# Altium schematic sheet [02] - GSM.SchDoc
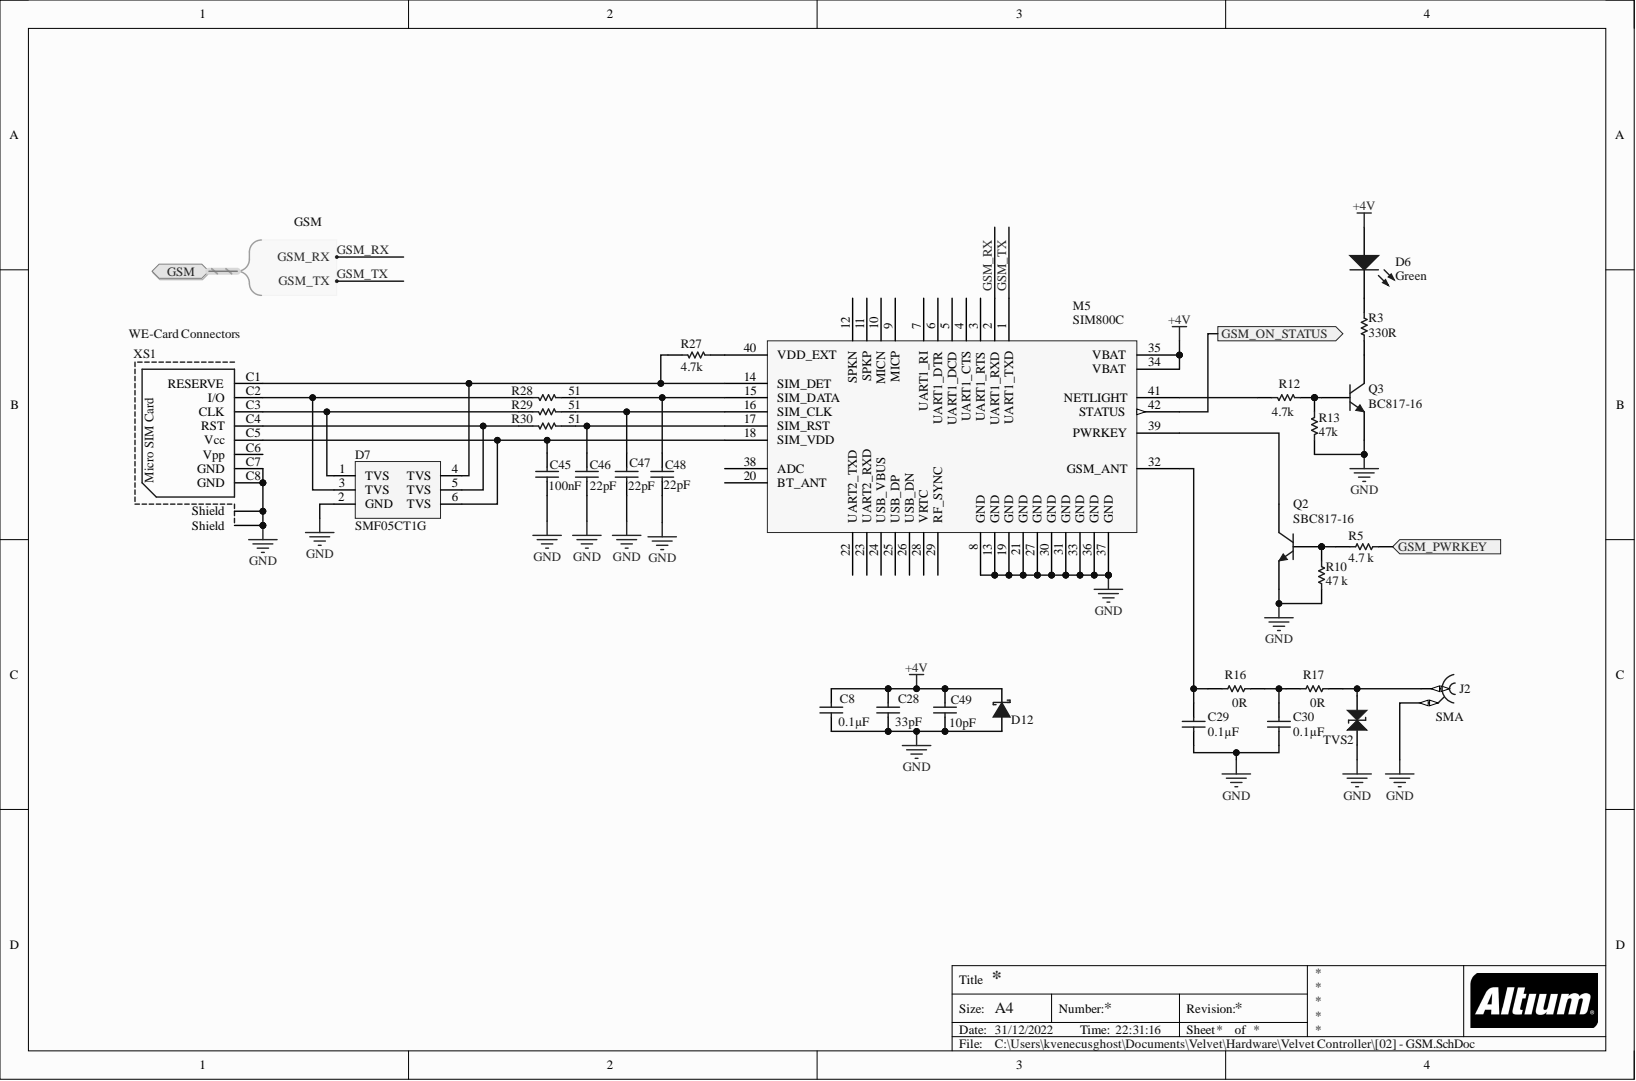
[diagram p0: [02] - GSM.SchDoc]

## Parts
C8  Capacitance: 0.1µF  [0603_C]  (hidden comment: Capacitor)
C28  Capacitance: 33pF  [0603_C]  (hidden comment: Capacitor)
C29  Capacitance: 0.1µF  [0603_C]  (hidden comment: Capacitor)
C30  Capacitance: 0.1µF  [0603_C]  (hidden comment: Capacitor)
C45  Capacitance: 100nF  [0603_C]  (hidden comment: Capacitor)
C46  Capacitance: 22pF  [0603_C]  (hidden comment: Capacitor)
C47  Capacitance: 22pF  [0603_C]  (hidden comment: Capacitor)
C48  Capacitance: 22pF  [0603_C]  (hidden comment: Capacitor)
C49  Capacitance: 10pF  [0603_C]  (hidden comment: Capacitor)
D6  Colour: Green  [Led 0805 green]  (hidden comment: LED)
D7  SMF05CT1G  [? TVS SMF05CT]
D12  D Schottky_1  [SOD-323]  (value not drawn)
J2  SMA  [AMPHENOL_132134]
M5  SIM800C  [GSM SIM800C]
Q2  SBC817-16  [SOT23-3]
Q3  BC817-16  [SOT23-3]
R3  Resistance: 330R  [0603_R]  (hidden comment: Res1)
R5  Resistance: 4.7 k  [0603_R]  (hidden comment: Res1)
R10  Resistance: 47 k  [0603_R]  (hidden comment: Res1)
R12  Resistance: 4.7k  [0603_R]  (hidden comment: Res1)
R13  Resistance: 47k  [0603_R]  (hidden comment: Res1)
R16  Resistance: 0R  [0603_R]  (hidden comment: Res1)
R17  Res1  [0603_R]  (value not drawn)
R27  Resistance: 4.7k  [0603_R]  (hidden comment: Res1)
R28  Res1  [0603_R]  (value not drawn)
R29  Res1  [0603_R]  (value not drawn)
R30  Res1  [0603_R]  (value not drawn)
TVS2  LXE15AAA1-153  [LXES15_MUR-L]  (value not drawn)
XS1  WE-Card Connectors  [693022010811]

## Ports
GSM, GSM.GSM_RX, GSM.GSM_TX, GSM_ON_STATUS, GSM_PWRKEY

## Nets
+4V: C8.2 C28.2 C49.2 D6.1 D12.1 M5.34(VBAT) M5.35(VBAT)
GND: C8.1 C28.1 C29.1 C30.1 C45.1 C46.1 C47.1 C48.1 C49.1 D7.2(GND) D12.2 J2.2 M5.8(GND) M5.13(GND) M5.19(GND) M5.21(GND) M5.27(GND) M5.30(GND) M5.31(GND) M5.33(GND) M5.36(GND) M5.37(GND) Q2.2 Q3.2 R10.1 R13.1 TVS2.1 XS1.C7(GND) XS1.C8(GND) XS1.Z1(Shield) XS1.Z2(Shield)
GSM_ON_STATUS: M5.42(STATUS) ; port GSM_ON_STATUS
GSM_PWRKEY: R5.2 ; port GSM_PWRKEY
GSM_RX: M5.2(UART1_RXD) ; port GSM
GSM_TX: M5.1(UART1_TXD) ; port GSM
NetC29_2: C29.2 M5.32(GSM_ANT) R16.2
NetC30_2: C30.2 R16.1 R17.2
NetC45_2: C45.2 D7.6(TVS) M5.18(SIM_VDD) XS1.C5(Vcc)
NetC46_2: C46.2 M5.17(SIM_RST) R30.1
NetC47_2: C47.2 M5.16(SIM_CLK) R29.1
NetC48_2: C48.2 M5.15(SIM_DATA) R28.1
NetD6_2: D6.2 R3.2
NetD7_1: D7.1(TVS) R29.2 XS1.C3(CLK)
NetD7_3: D7.3(TVS) R28.2 XS1.C2(I/O)
NetD7_4: D7.4(TVS) M5.14(SIM_DET) R27.2 XS1.C1(RESERVE)
NetD7_5: D7.5(TVS) R30.2 XS1.C4(RST)
NetJ2_1: J2.1 R17.1 TVS2.2
NetM5_39: M5.39(PWRKEY) Q2.3
NetM5_40: M5.40(VDD_EXT) R27.1
NetM5_41: M5.41(NETLIGHT) R12.1
NetQ2_1: Q2.1 R5.1 R10.2
NetQ3_1: Q3.1 R12.2 R13.2
NetQ3_3: Q3.3 R3.1

Not connected: M5 3(UART1_RTS) 4(UART1_CTS) 5(UART1_DCD) 6(UART1_DTR) 7(UART1_RI) 9(MICP) 10(MICN) 11(SPKP) 12(SPKN) 20(BT_ANT) 22(UART2_TXD) 23(UART2_RXD) 24(USB_VBUS) 25(USB_DP) 26(USB_DN) 28(VRTC) 29(RF_SYNC) 38(ADC); XS1 C6(Vpp)

## Library data of the parts
C8 C28-C30 C45-C49 = 1: Chip Capacitor Nonpolar; Manufacturer: Kemet; Manufacturer Part Number: C0603C104K5RACTU; Database Table Name: Capacitors$; Temperature Coefficient: X7R; Tolerance: ±10%; Voltage - Rated: 50V; Applications: General Purpose; Category: Capacitors; Component Kind: Standard; ComponentLink1Description: http://www.kemet.com/docfinder?Partnumber=C0603C104K5RACTU; ComponentLink1URL: http://www.kemet.com/docfinder?Partnumber=C0603C104K5RACTU; Features: -; Height - Seated (Max): -; Lead Spacing: -; Lead Style: -; Library Name: Altium_Library.DbLib; Mounting Type: Surface Mount, MLCC; Operating Temperature: -55°C ~ 125°C; Package / Case: 0603 (1608 Metric); Packaging: Cut Tape (CT); Pricing 1: 1=0.1, 10=0.041, 50=0.0222, 100=0.0187, 250=0.01532, 500=0.0131, 1000=0.0102 (USD); Ratings: -; RoHS: RoHS Compliant; Size / Dimension: 0.063" L x 0.031" W (1.60mm x 0.80mm); Stock 1: 3992655; Supplier 1: Digi-Key; Supplier Part Number 1: 399-5089-1-ND; Thickness (Max): 0.034" (0.87mm)
D6 = 3: Led 0805; Manufacturer: Vishay Lite-On; Manufacturer Part Number: LTST-S220GKT; Database Table Name: Devises$; Dominant Wavelength: 569nm; Forward Current: 30mA; Forward Voltage: 2.1V; Lens Colour: Clear,Colorless; Lens Style: Clear,Diffused,Colorless,Rectangular; Lens Transparency: Clear; Luminous Intensity: 10mcd; Number of Elements: 1; Power Dissipation: 100mW; Reverse Voltage: 5V; Test Current: 20mA; Viewing Angle: 130°; Wavelength: 565nm; Case Code (Imperial): 0805; Case Code (Metric): 2012; Case/Package: 0805; Component Kind: Standard; ComponentLink1Description: https://datasheet.ciiva.com/2110/ltst-s220gkt-2110016.pdf; ComponentLink1URL: https://datasheet.ciiva.com/2110/ltst-s220gkt-2110016.pdf; ComponentLink2Description: https://datasheet.ciiva.com/11775/0900766b80dc0b6e-11775442.pdf; ComponentLink2URL: https://datasheet.ciiva.com/11775/0900766b80dc0b6e-11775442.pdf; ComponentLink3Description: https://datasheet.ciiva.com/15118/getdatasheetpartid-221136-15118117.pdf; ComponentLink3URL: https://datasheet.ciiva.com/15118/getdatasheetpartid-221136-15118117.pdf; ComponentLink4Description: https://datasheet.ciiva.com/2110/ltst-s220gkt-2110017.pdf; ComponentLink4URL: https://datasheet.ciiva.com/2110/ltst-s220gkt-2110017.pdf; ComponentLink5Description: https://datasheet.ciiva.com/16444/ltst-s220gkt-16444773.pdf; ComponentLink5URL: https://datasheet.ciiva.com/16444/ltst-s220gkt-16444773.pdf; Depth: 0.6mm; Height: 0.6mm; ID: 3; Illumination Colour: Green; Lead Free: Lead Free; Length: 2.1mm; Library Name: Altium_Library.DbLib; Max Operating Temperature: 85°C; Min Operating Temperature: -55°C; Mount: Surface Mount; Number of LEDs: 1; Number of Pins: 2; Package Quantity: 1; Packaging: Tape and Reel; Power Rating: 100mW; Pricing 1: 1=0.27, 10=0.199, 25=0.1464, 100=0.1045, 250=0.07108, 500=0.0627, 1000=0.04912 (USD); Radiation Hardening: No; Stock 1: 87001; Supplier 1: Digi-Key; Supplier Part Number 1: 160-1218-6-ND; Width: 1mm
D7 = SMF05CT1G: 5-Line Transient Voltage  Suppressor Array; Manufacturer: ON Semiconductor; Capacitance @ Frequency: 80pF @ 1MHz; Current - Peak Pulse (10/1000µs): 8A (8/20µs); Power - Peak Pulse: 100W; Power Line Protection: No; Type: Zener; Unidirectional Channels: 5; Voltage - Breakdown (Min): 6.2V; Voltage - Clamping (Max) @ Ipp: 12.5V; Voltage - Reverse Standoff (Typ): 5V (Max); Applications: General Purpose; Bidirectional Channels: -; Category: Circuit Protection; Component Kind: Standard; ComponentLink1Description: http://www.onsemi.com/pub_link/Collateral/SMF05C-D.PDF; ComponentLink1URL: http://www.onsemi.com/pub_link/Collateral/SMF05C-D.PDF; Library Name: SIM68V.SchLib; Manufacturer Part Number: SMF05CT1G; Mounting Type: Surface Mount; Operating Temperature: -40°C ~ 125°C; Package / Case: 6-TSSOP, SC-88, SOT-363; Packaging: Cut Tape (CT); Pricing 1: 1=0.59, 10=0.413, 25=0.3392, 100=0.2709, 250=0.19736, 500=0.16026, 1000=0.12319 (USD); RoHS: RoHS Compliant; Stock 1: 13951; Supplier 1: Digi-Key; Supplier Device Package: SC-88/SC70-6/SOT-363; Supplier Part Number 1: SMF05CT1GOSCT-ND
D12 = D Schottky_1: SMAZ16-13-F; Manufacturer: Diodes Incorporated; Pd - Power Dissipation: 1 W; Vz - Zener Voltage: 16 V; Zener Current: 0.5 uA; Component Kind: Standard; Manufacturer Part Number: SMAZ16-13-F; Maximum Operating Temperature: + 150 C; Minimum Operating Temperature: - 65 C; Mounting Style: SMD/SMT; Package / Case: SMA-2; Product Category: Zener Diodes; Supplier Part Number: SMAZ16-FDICT-ND; Library Name: Blue Tractor-2021.SchLib
J2 = AMPHENOL_132134: Manufacturer: TE Connectivity; Manufacturer Part Number: 5-1814832-1; Body Material: Zinc; Body Plating: Gold; Connector System: Cable-to-Board; Connector Type: Jack,SMA; Dielectric Material: PTFE; Frequency: 3GHz; Gender: Female; Impedance: 50R; Insulation Resistance: 5GR; Number of Positions: 1; Plating: Nickel; Polarity: Normal; Sealable: No (Without); Tail Length: 4mm; Wire/Cable Type: Coaxial; Case/Package: SMA; Component Kind: Standard; Contact Material: Bronze; Contact Plating: Gold; Database Table Name: AMPHENOL; Depth: 6.4mm; ELV: Compliant; Family: SMA; Fastening Type: Threaded; Insulation Material: Polytetrafluoroethylene; Lead Free: Lead Free; Length: 0.532inch; Library Name: Connectors.DbLib; Max Frequency: 3GHz; Max Operating Temperature: 185°C; Min Operating Temperature: -67°C; Mount: PCB,Through Hole; Orientation: Straight; Package Quantity: 1; Packaging: Box; Pricing 1: 1=2.21, 10=2.121, 25=1.944, 50=1.8556, 100=1.7673, 250=1.54636, 500=1.50218, 1000=1.32545 (USD); Radiation Hardening: No; REACH SVHC: No SVHC; Stock 1: 20161; Supplier 1: Digi-Key; Supplier Part Number 1: A97594-ND; Termination: Through Hole; Author: CERN DEM JLC
M5 = SIM800C: GSM/GPS module; Component Kind: Standard; Library Name: Blue Tractor-2021.SchLib
Q2 Q3 = BC817-16: NPN General-purpose Transistor; Manufacturer: ON Semiconductor; Manufacturer Part Number: BC817-16LT3G; Collector Base Voltage (VCBO): 50V; Collector Emitter Breakdown Voltage: 45V; Collector Emitter Saturation Voltage: 700mV; Element Configuration: Single; Emitter Base Voltage (VEBO): 5V; Frequency: 100MHz; hFE Min: 100; Max Collector Current: 500mA; Max Power Dissipation: 300mW; Number of Elements: 1; Case/Package: SOT-23-3; Collector Emitter Voltage (VCEO): 45V; Component Kind: Standard; ComponentLink1Description: http://www.mccsemi.com/up_pdf/BC817-16~BC817-40(SOT-23).pdf; ComponentLink1URL: http://www.mccsemi.com/up_pdf/BC817-16~BC817-40(SOT-23).pdf; ComponentLink2Description: https://datasheet.ciiva.com/15139/getdatasheetpartid-84401-15139109.pdf; ComponentLink2URL: https://datasheet.ciiva.com/15139/getdatasheetpartid-84401-15139109.pdf; ComponentLink3Description: https://datasheet.ciiva.com/980/bc817-16lt1-d-980715.pdf; ComponentLink3URL: https://datasheet.ciiva.com/980/bc817-16lt1-d-980715.pdf; ComponentLink4Description: https://datasheet.ciiva.com/26115/bc817-16lt1-d-26115598.pdf; ComponentLink4URL: https://datasheet.ciiva.com/26115/bc817-16lt1-d-26115598.pdf; ComponentLink5Description: https://datasheet.ciiva.com/11204/bc817-16lt1-d-11204335.pdf; ComponentLink5URL: https://datasheet.ciiva.com/11204/bc817-16lt1-d-11204335.pdf; Contact Plating: Tin,Matte; Gain Bandwidth Product: 100MHz; Halogen Free: Halogen Free; Lead Free: Lead Free; Max Breakdown Voltage: 45V; Max Operating Temperature: 150°C; Min Operating Temperature: -55°C; Number of Pins: 3; Package Quantity: 10000; Packaging: Tape and Reel; Polarity: NPN; Power Dissipation: 300mW; Pricing 1: 1=0.13, 10=0.121, 100=0.0658, 500=0.04048, 1000=0.02759 (USD); Radiation Hardening: No; Stock 1: 22032; Supplier 1: Digi-Key; Supplier Part Number 1: BC817-16LT3GOSDKR-ND; Transition Frequency: 100MHz
  Q2: Signal Integrity: BC817-16; Simulation: QBC817-16; Library Name: Blue Tractor-2021.SchLib
  Q3: Library Name: Philips Discrete BJT - Low Power.IntLib
R3 = Res1: Chip Resistor; Manufacturer: Panasonic; Manufacturer Part Number: ERJ-3GEYJ103V; Max Power Dissipation: 100mW; Temperature Coefficient: 200ppm/°C; Tolerance: 5%; Voltage Rating: 75V; Voltage Rating (DC): 50V; Case Code (Imperial): 0603; Case Code (Metric): 1608; Case/Package: 0603; Component Kind: Standard; ComponentLink1Description: https://datasheet.ciiva.com/34303/2007265-34303757.pdf; ComponentLink1URL: https://datasheet.ciiva.com/34303/2007265-34303757.pdf; ComponentLink2Description: https://datasheet.ciiva.com/11809/0900766b80f806dd-11809566.pdf; ComponentLink2URL: https://datasheet.ciiva.com/11809/0900766b80f806dd-11809566.pdf; ComponentLink3Description: https://datasheet.ciiva.com/28514/1678796-28514213.pdf; ComponentLink3URL: https://datasheet.ciiva.com/28514/1678796-28514213.pdf; ComponentLink4Description: https://datasheet.ciiva.com/30147/4aoa0000ce1-30147029.pdf; ComponentLink4URL: https://datasheet.ciiva.com/30147/4aoa0000ce1-30147029.pdf; ComponentLink5Description: https://datasheet.ciiva.com/46371/1678796-46371909.pdf; ComponentLink5URL: https://datasheet.ciiva.com/46371/1678796-46371909.pdf; ComponentLink6Description: https://datasheet.ciiva.com/24133/aoa0000ce1-37422-24133064.pdf; ComponentLink6URL: https://datasheet.ciiva.com/24133/aoa0000ce1-37422-24133064.pdf; ComponentLink7Description: https://datasheet.ciiva.com/46385/1678796-46385240.pdf; ComponentLink7URL: https://datasheet.ciiva.com/46385/1678796-46385240.pdf; ComponentLink8Description: https://datasheet.ciiva.com/17212/aoa0000ce1-17212106.pdf; ComponentLink8URL: https://datasheet.ciiva.com/17212/aoa0000ce1-17212106.pdf; ComponentLink9Description: https://datasheet.ciiva.com/9117/aoa0000ce1-9117038.pdf; ComponentLink9URL: https://datasheet.ciiva.com/9117/aoa0000ce1-9117038.pdf; ComponentLink10Description: https://datasheet.ciiva.com/16740/aoa0000ce1-16740655.pdf; ComponentLink10URL: https://datasheet.ciiva.com/16740/aoa0000ce1-16740655.pdf; ComponentLink11Description: https://datasheet.ciiva.com/48531/aoa0000ce1-48531887.pdf; ComponentLink11URL: https://datasheet.ciiva.com/48531/aoa0000ce1-48531887.pdf; Composition: Thick Film; Contact Plating: Tin; Depth: 0.8mm; Features: Automotive AEC-Q200; Height: 0.45mm; Lead Free: Lead Free; Length: 1.6mm; Max Operating Temperature: 155°C; Military Standard: Not; Min Operating Temperature: -55°C; Mount: Surface Mount; Number of Terminations: 2; Package Quantity: 1; Packaging: Tape and Reel; Power Rating: 100mW; Pricing 1: 1=0.1, 10=0.054, 100=0.022, 1000=0.00988, 2500=0.00858 (USD); REACH SVHC: No SVHC; Stock 1: 10740434; Supplier 1: Digi-Key; Supplier Part Number 1: P10KGDKR-ND; Termination: SMD/SMT; Weight: 7.1E-05oz; Width: 0.8mm; Working Voltage: 75V; Library Name: Blue Tractor-2021.SchLib
R5 R10 = 1: Chip Resistor; Manufacturer: Panasonic; Manufacturer Part Number: ERJ-3GEYJ103V; Database Table Name: Resistors$; Max Power Dissipation: 100mW; Temperature Coefficient: 200ppm/°C; Tolerance: 5%; Voltage Rating: 75V; Voltage Rating (DC): 50V; Case Code (Imperial): 0603; Case Code (Metric): 1608; Case/Package: 0603; Component Kind: Standard; ComponentLink1Description: https://datasheet.ciiva.com/34303/2007265-34303757.pdf; ComponentLink1URL: https://datasheet.ciiva.com/34303/2007265-34303757.pdf; ComponentLink2Description: https://datasheet.ciiva.com/11809/0900766b80f806dd-11809566.pdf; ComponentLink2URL: https://datasheet.ciiva.com/11809/0900766b80f806dd-11809566.pdf; ComponentLink3Description: https://datasheet.ciiva.com/28514/1678796-28514213.pdf; ComponentLink3URL: https://datasheet.ciiva.com/28514/1678796-28514213.pdf; ComponentLink4Description: https://datasheet.ciiva.com/30147/4aoa0000ce1-30147029.pdf; ComponentLink4URL: https://datasheet.ciiva.com/30147/4aoa0000ce1-30147029.pdf; ComponentLink5Description: https://datasheet.ciiva.com/46371/1678796-46371909.pdf; ComponentLink5URL: https://datasheet.ciiva.com/46371/1678796-46371909.pdf; ComponentLink6Description: https://datasheet.ciiva.com/24133/aoa0000ce1-37422-24133064.pdf; ComponentLink6URL: https://datasheet.ciiva.com/24133/aoa0000ce1-37422-24133064.pdf; ComponentLink7Description: https://datasheet.ciiva.com/46385/1678796-46385240.pdf; ComponentLink7URL: https://datasheet.ciiva.com/46385/1678796-46385240.pdf; ComponentLink8Description: https://datasheet.ciiva.com/17212/aoa0000ce1-17212106.pdf; ComponentLink8URL: https://datasheet.ciiva.com/17212/aoa0000ce1-17212106.pdf; ComponentLink9Description: https://datasheet.ciiva.com/9117/aoa0000ce1-9117038.pdf; ComponentLink9URL: https://datasheet.ciiva.com/9117/aoa0000ce1-9117038.pdf; ComponentLink10Description: https://datasheet.ciiva.com/16740/aoa0000ce1-16740655.pdf; ComponentLink10URL: https://datasheet.ciiva.com/16740/aoa0000ce1-16740655.pdf; ComponentLink11Description: https://datasheet.ciiva.com/48531/aoa0000ce1-48531887.pdf; ComponentLink11URL: https://datasheet.ciiva.com/48531/aoa0000ce1-48531887.pdf; Composition: Thick Film; Contact Plating: Tin; Depth: 0.8mm; Features: Automotive AEC-Q200; Height: 0.45mm; Lead Free: Lead Free; Length: 1.6mm; Library Name: Altium_Library.DbLib; Max Operating Temperature: 155°C; Military Standard: Not; Min Operating Temperature: -55°C; Mount: Surface Mount; Number of Terminations: 2; Package Quantity: 1; Packaging: Tape and Reel; Power Rating: 100mW; Pricing 1: 1=0.1, 10=0.054, 100=0.022, 1000=0.00988, 2500=0.00858 (USD); REACH SVHC: No SVHC; Stock 1: 10740434; Supplier 1: Digi-Key; Supplier Part Number 1: P10KGDKR-ND; Termination: SMD/SMT; Weight: 7.1E-05oz; Width: 0.8mm; Working Voltage: 75V
R12 R13 R27 = 1: Chip Resistor; Manufacturer: Panasonic; Manufacturer Part Number: ERJ-3EKF3300V; Database Table Name: Resistors$; Max Power Dissipation: 100mW; Temperature Coefficient: 100ppm/°C; Tolerance: 1%; Voltage Rating: 75V; Case Code (Imperial): 0603; Case Code (Metric): 1608; Case/Package: 0603; Component Kind: Standard; ComponentLink1Description: http://industrial.panasonic.com/www-cgi/jvcr13pz.cgi?E+PZ+3+AOA0002+ERJ6ENF3000V+7+WW; ComponentLink1URL: http://industrial.panasonic.com/www-cgi/jvcr13pz.cgi?E+PZ+3+AOA0002+ERJ6ENF3000V+7+WW; ComponentLink2Description: https://datasheet.ciiva.com/7886/aoa0000ce2-7886729.pdf; ComponentLink2URL: https://datasheet.ciiva.com/7886/aoa0000ce2-7886729.pdf; ComponentLink3Description: https://datasheet.ciiva.com/48531/aoa0000ce2-48531001.pdf; ComponentLink3URL: https://datasheet.ciiva.com/48531/aoa0000ce2-48531001.pdf; ComponentLink4Description: https://datasheet.ciiva.com/22721/426256-22721099.pdf; ComponentLink4URL: https://datasheet.ciiva.com/22721/426256-22721099.pdf; ComponentLink5Description: https://datasheet.ciiva.com/46373/1678782-46373899.pdf; ComponentLink5URL: https://datasheet.ciiva.com/46373/1678782-46373899.pdf; ComponentLink6Description: https://datasheet.ciiva.com/9117/aoa0000ce2-9117132.pdf; ComponentLink6URL: https://datasheet.ciiva.com/9117/aoa0000ce2-9117132.pdf; ComponentLink7Description: https://datasheet.ciiva.com/46373/1678782-46373900.pdf; ComponentLink7URL: https://datasheet.ciiva.com/46373/1678782-46373900.pdf; Composition: Thick Film; Contact Plating: Tin; Depth: 0.8mm; Features: Automotive AEC-Q200; Height: 0.022inch; Lead Free: Lead Free; Length: 1.6mm; Library Name: Altium_Library.DbLib; Max Operating Temperature: 155°C; Military Standard: Not; Min Operating Temperature: -55°C; Mount: Surface Mount; Number of Pins: 2; Number of Terminations: 2; Package Quantity: 1; Packaging: Tape and Reel; Power Rating: 100mW; Pricing 1: 1=0.1, 10=0.079, 100=0.0323, 1000=0.01449, 2500=0.01258 (USD); Radiation Hardening: No; REACH SVHC: No SVHC; Stock 1: 924496; Supplier 1: Digi-Key; Supplier Part Number 1: P330HDKR-ND; Termination: SMD/SMT; Voltage Rating (DC): 75V; Weight: 7.1E-05oz; Width: 0.8mm
R16 = 1: Chip Resistor; Manufacturer: Panasonic; Manufacturer Part Number: ERJ-3GEY0R00V; Database Table Name: Resistors$; Max Power Dissipation: 100mW; Temperature Coefficient: 600ppm/°C; Tolerance: 0%; Voltage Rating: 75V; Voltage Rating (DC): 50V; Case Code (Imperial): 0603; Case Code (Metric): 1608; Case/Package: 0603; Component Kind: Standard; ComponentLink1Description: https://datasheet.ciiva.com/27055/1935748-27055113.pdf; ComponentLink1URL: https://datasheet.ciiva.com/27055/1935748-27055113.pdf; ComponentLink2Description: https://datasheet.ciiva.com/11808/0900766b80f806dd-11808207.pdf; ComponentLink2URL: https://datasheet.ciiva.com/11808/0900766b80f806dd-11808207.pdf; ComponentLink3Description: https://datasheet.ciiva.com/48532/aoa0000ce1-48532165.pdf; ComponentLink3URL: https://datasheet.ciiva.com/48532/aoa0000ce1-48532165.pdf; ComponentLink4Description: https://datasheet.ciiva.com/43460/1678796-43460647.pdf; ComponentLink4URL: https://datasheet.ciiva.com/43460/1678796-43460647.pdf; ComponentLink5Description: https://datasheet.ciiva.com/28695/1678796-28695833.pdf; ComponentLink5URL: https://datasheet.ciiva.com/28695/1678796-28695833.pdf; ComponentLink6Description: https://datasheet.ciiva.com/5959/1678796-5959707.pdf; ComponentLink6URL: https://datasheet.ciiva.com/5959/1678796-5959707.pdf; ComponentLink7Description: https://datasheet.ciiva.com/16834/aoa0000ce1-16834828.pdf; ComponentLink7URL: https://datasheet.ciiva.com/16834/aoa0000ce1-16834828.pdf; ComponentLink8Description: https://datasheet.ciiva.com/17215/aoa0000ce1-17215388.pdf; ComponentLink8URL: https://datasheet.ciiva.com/17215/aoa0000ce1-17215388.pdf; ComponentLink9Description: https://datasheet.ciiva.com/46377/1678796-46377868.pdf; ComponentLink9URL: https://datasheet.ciiva.com/46377/1678796-46377868.pdf; ComponentLink10Description: https://datasheet.ciiva.com/30199/4aoa0000ce1-30199822.pdf; ComponentLink10URL: https://datasheet.ciiva.com/30199/4aoa0000ce1-30199822.pdf; ComponentLink11Description: https://datasheet.ciiva.com/9198/aoa0000ce1-9198975.pdf; ComponentLink11URL: https://datasheet.ciiva.com/9198/aoa0000ce1-9198975.pdf; ComponentLink12Description: https://datasheet.ciiva.com/16758/70255313-16758527.pdf; ComponentLink12URL: https://datasheet.ciiva.com/16758/70255313-16758527.pdf; Composition: Thick Film; Contact Plating: Tin; Depth: 0.8mm; Features: Automotive AEC-Q200; Height: 0.45mm; Lead Free: Lead Free; Length: 1.6mm; Library Name: Altium_Library.DbLib; Max Operating Temperature: 155°C; Military Standard: Not; Min Operating Temperature: -55°C; Mount: Surface Mount; Number of Terminations: 2; Package Quantity: 1; Packaging: Tape and Reel; Power Rating: 100mW; Pricing 1: 1=0.1, 10=0.053, 25=0.038, 50=0.029, 100=0.0214, 250=0.01632, 500=0.01306, 1000=0.00962 (USD); Radiation Hardening: No; REACH SVHC: No SVHC; Stock 1: 19868266; Supplier 1: Digi-Key; Supplier Part Number 1: P0.0GDKR-ND; Termination: SMD/SMT; Width: 0.031inch
R17 = 1: Chip Resistor; Manufacturer: Panasonic; Manufacturer Part Number: ERJ-3GEY0R00V; Database Table Name: Resistors$; Max Power Dissipation: 100mW; Resistance: 0R; Temperature Coefficient: 600ppm/°C; Tolerance: 0%; Voltage Rating: 75V; Voltage Rating (DC): 50V; Case Code (Imperial): 0603; Case Code (Metric): 1608; Case/Package: 0603; Component Kind: Standard; ComponentLink1Description: https://datasheet.ciiva.com/27055/1935748-27055113.pdf; ComponentLink1URL: https://datasheet.ciiva.com/27055/1935748-27055113.pdf; ComponentLink2Description: https://datasheet.ciiva.com/11808/0900766b80f806dd-11808207.pdf; ComponentLink2URL: https://datasheet.ciiva.com/11808/0900766b80f806dd-11808207.pdf; ComponentLink3Description: https://datasheet.ciiva.com/48532/aoa0000ce1-48532165.pdf; ComponentLink3URL: https://datasheet.ciiva.com/48532/aoa0000ce1-48532165.pdf; ComponentLink4Description: https://datasheet.ciiva.com/43460/1678796-43460647.pdf; ComponentLink4URL: https://datasheet.ciiva.com/43460/1678796-43460647.pdf; ComponentLink5Description: https://datasheet.ciiva.com/28695/1678796-28695833.pdf; ComponentLink5URL: https://datasheet.ciiva.com/28695/1678796-28695833.pdf; ComponentLink6Description: https://datasheet.ciiva.com/5959/1678796-5959707.pdf; ComponentLink6URL: https://datasheet.ciiva.com/5959/1678796-5959707.pdf; ComponentLink7Description: https://datasheet.ciiva.com/16834/aoa0000ce1-16834828.pdf; ComponentLink7URL: https://datasheet.ciiva.com/16834/aoa0000ce1-16834828.pdf; ComponentLink8Description: https://datasheet.ciiva.com/17215/aoa0000ce1-17215388.pdf; ComponentLink8URL: https://datasheet.ciiva.com/17215/aoa0000ce1-17215388.pdf; ComponentLink9Description: https://datasheet.ciiva.com/46377/1678796-46377868.pdf; ComponentLink9URL: https://datasheet.ciiva.com/46377/1678796-46377868.pdf; ComponentLink10Description: https://datasheet.ciiva.com/30199/4aoa0000ce1-30199822.pdf; ComponentLink10URL: https://datasheet.ciiva.com/30199/4aoa0000ce1-30199822.pdf; ComponentLink11Description: https://datasheet.ciiva.com/9198/aoa0000ce1-9198975.pdf; ComponentLink11URL: https://datasheet.ciiva.com/9198/aoa0000ce1-9198975.pdf; ComponentLink12Description: https://datasheet.ciiva.com/16758/70255313-16758527.pdf; ComponentLink12URL: https://datasheet.ciiva.com/16758/70255313-16758527.pdf; Composition: Thick Film; Contact Plating: Tin; Depth: 0.8mm; Features: Automotive AEC-Q200; Height: 0.45mm; Lead Free: Lead Free; Length: 1.6mm; Library Name: Altium_Library.DbLib; Max Operating Temperature: 155°C; Military Standard: Not; Min Operating Temperature: -55°C; Mount: Surface Mount; Number of Terminations: 2; Package Quantity: 1; Packaging: Tape and Reel; Power Rating: 100mW; Pricing 1: 1=0.1, 10=0.053, 25=0.038, 50=0.029, 100=0.0214, 250=0.01632, 500=0.01306, 1000=0.00962 (USD); Radiation Hardening: No; REACH SVHC: No SVHC; Stock 1: 19868266; Supplier 1: Digi-Key; Supplier Part Number 1: P0.0GDKR-ND; Termination: SMD/SMT; Width: 0.031inch
R28-R30 = 1: Chip Resistor; Manufacturer: Panasonic; Manufacturer Part Number: ERJ-3EKF3300V; Database Table Name: Resistors$; Max Power Dissipation: 100mW; Resistance: 51; Temperature Coefficient: 100ppm/°C; Tolerance: 1%; Voltage Rating: 75V; Case Code (Imperial): 0603; Case Code (Metric): 1608; Case/Package: 0603; Component Kind: Standard; ComponentLink1Description: http://industrial.panasonic.com/www-cgi/jvcr13pz.cgi?E+PZ+3+AOA0002+ERJ6ENF3000V+7+WW; ComponentLink1URL: http://industrial.panasonic.com/www-cgi/jvcr13pz.cgi?E+PZ+3+AOA0002+ERJ6ENF3000V+7+WW; ComponentLink2Description: https://datasheet.ciiva.com/7886/aoa0000ce2-7886729.pdf; ComponentLink2URL: https://datasheet.ciiva.com/7886/aoa0000ce2-7886729.pdf; ComponentLink3Description: https://datasheet.ciiva.com/48531/aoa0000ce2-48531001.pdf; ComponentLink3URL: https://datasheet.ciiva.com/48531/aoa0000ce2-48531001.pdf; ComponentLink4Description: https://datasheet.ciiva.com/22721/426256-22721099.pdf; ComponentLink4URL: https://datasheet.ciiva.com/22721/426256-22721099.pdf; ComponentLink5Description: https://datasheet.ciiva.com/46373/1678782-46373899.pdf; ComponentLink5URL: https://datasheet.ciiva.com/46373/1678782-46373899.pdf; ComponentLink6Description: https://datasheet.ciiva.com/9117/aoa0000ce2-9117132.pdf; ComponentLink6URL: https://datasheet.ciiva.com/9117/aoa0000ce2-9117132.pdf; ComponentLink7Description: https://datasheet.ciiva.com/46373/1678782-46373900.pdf; ComponentLink7URL: https://datasheet.ciiva.com/46373/1678782-46373900.pdf; Composition: Thick Film; Contact Plating: Tin; Depth: 0.8mm; Features: Automotive AEC-Q200; Height: 0.022inch; Lead Free: Lead Free; Length: 1.6mm; Library Name: Altium_Library.DbLib; Max Operating Temperature: 155°C; Military Standard: Not; Min Operating Temperature: -55°C; Mount: Surface Mount; Number of Pins: 2; Number of Terminations: 2; Package Quantity: 1; Packaging: Tape and Reel; Power Rating: 100mW; Pricing 1: 1=0.1, 10=0.079, 100=0.0323, 1000=0.01449, 2500=0.01258 (USD); Radiation Hardening: No; REACH SVHC: No SVHC; Stock 1: 924496; Supplier 1: Digi-Key; Supplier Part Number 1: P330HDKR-ND; Termination: SMD/SMT; Voltage Rating (DC): 75V; Weight: 7.1E-05oz; Width: 0.8mm
TVS2 = TVS-LXE15AAA1-153: Component Kind: Standard; Library Name: Blue Tractor-2021.SchLib
XS1 = WE-Card Connectors 693022010811: WR-CRD Micro SIM_Hinge_8 pins; Manufacturer: Würth Elektronik eiSos GmbH; ComponentLink1 Description: Manufacturer; ComponentLink2 Description: Datasheet; NoPins: 8; Component Kind: Standard; ComponentLink1URL: http://www.we-online.de; ComponentLink2URL: http://katalog.we-online.de/em/datasheet/693022010811.pdf; Created Date: 10/20/2014; partNo: 693022010811; Created by: Karrer Zheng; Library Name: Blue Tractor-2021.SchLib
